# Altium schematic sheet Sheet1.SchDoc(Sheet1)
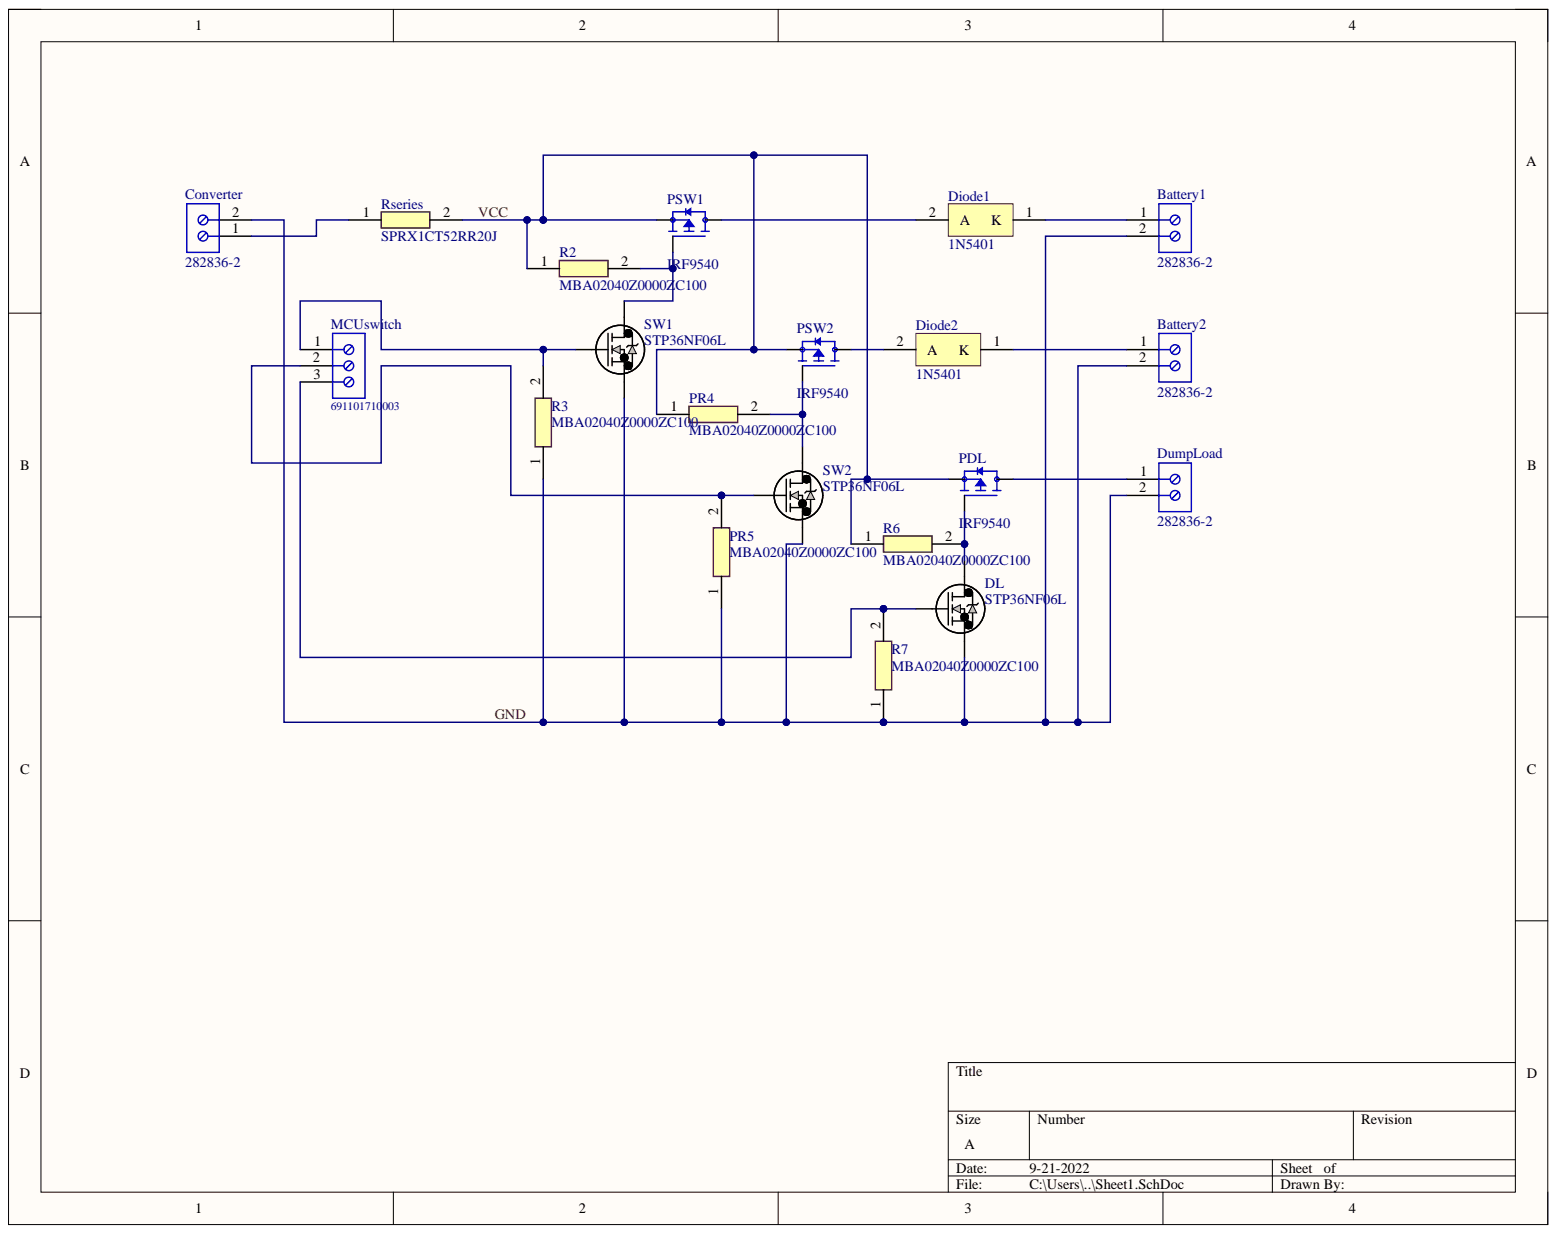
[diagram p0: Sheet1.SchDoc]

## Parts
Battery1  282836-2  [TECO-282836-2_V]
Battery2  282836-2  [TECO-282836-2_V]
Converter  282836-2  [TECO-282836-2_V]
Diode1  1N5401  [ONSC-AXIAL_LEAD-2-267-05_V]
Diode2  1N5401  [ONSC-AXIAL_LEAD-2-267-05_V]
DL  STP36NF06L  [TO-220_STM]
DumpLoad  282836-2  [TECO-282836-2_V]
MCUswitch  691101710003  [691101710003]
PDL  IRF9540  [TO254P1052X465X1989-3]
PR4  MBA02040Z0000ZC100  [RESAD1000W50L360D160]
PR5  MBA02040Z0000ZC100  [RESAD1000W50L360D160]
PSW1  IRF9540  [TO254P1052X465X1989-3]
PSW2  IRF9540  [TO254P1052X465X1989-3]
R2  MBA02040Z0000ZC100  [RESAD1000W50L360D160]
R3  MBA02040Z0000ZC100  [RESAD1000W50L360D160]
R6  MBA02040Z0000ZC100  [RESAD1000W50L360D160]
R7  MBA02040Z0000ZC100  [RESAD1000W50L360D160]
Rseries  SPRX1CT52RR20J  [RESAD2300W80L900D350]
SW1  STP36NF06L  [TO-220_STM]
SW2  STP36NF06L  [TO-220_STM]

## Nets
GND: Battery1.2 Battery2.2 Converter.2 DL.3 DumpLoad.2 PR5.1 R3.1 R7.1 SW1.3 SW2.3
VCC: PDL.3 PR4.1 PSW1.3 PSW2.3 R2.1 R6.1 Rseries.2
NetBattery1_1: Battery1.1 Diode1.1
NetBattery2_1: Battery2.1 Diode2.1
NetConverter_1: Converter.1 Rseries.1
NetDiode1_2: Diode1.2 PSW1.2
NetDiode2_2: Diode2.2 PSW2.2
NetDL_1: DL.1 MCUswitch.3 R7.2
NetDL_2: DL.2 PDL.1 R6.2
NetDumpLoad_1: DumpLoad.1 PDL.2
NetMCUswitch_1: MCUswitch.1 R3.2 SW1.1
NetMCUswitch_2: MCUswitch.2 PR5.2 SW2.1
NetPR4_2: PR4.2 PSW2.1 SW2.2
NetPSW1_1: PSW1.1 R2.2 SW1.2

## Library data of the parts
Battery1 Battery2 Converter DumpLoad = CMP-1684-00017-1: Female Header, Pitch 5 mm, 1 x 2 Position, Height 10 mm, Tail Length 3.5 mm, Rohs, Bulk; Manufacturer: TE Connectivity; 3D Model: 282836-2.pdf; Clamp Material - Plating: Brass-NickelPlated; Current: 13.5A; Dynamic Catalog: BuchananSeries; Featured Product: EurostyleTerminalBlockConnectors; Mating Orientation: HorizontalwithBoard; Number of Levels: 1; Other Names: 5ULV-02B  A98076; Pitch: 0.197"(5.00mm); Positions Per Level: 2; Product Training Modules: Wire-to-BoardEurostyleTerminalBlocks; Screw Thread: M3; Torque - Screw: 0.68Nm(6Lb-In); Voltage: 300V; Wire Gauge: 16-30AWG; Wire Termination: Screw-RisingCageClamp; Category: Connectors,Interconnects; Color: Green; Component Kind: Standard; Contact Material - Plating: Brass-TinPlated; Datasheet URL: http://www.te.com.cn/commerce/DocumentDelivery/DDEController?Action=showdoc&DocId=Catalog+Section%7F1308389_EUROSTYLE_TERMINAL_BLOCKS%7F0607%7Fpdf%7FEnglish%7FENG_CS_1308389_EUROSTYLE_TERMINAL_BLOCKS_0607.pdf%7F282836-2; Family: TerminalBlocks-WiretoBoard; Features: Interlocking(Side); Housing Material: Polyamide(PA66),Nylon6/6; Library Name: Altium Content Vault; Manufacturer URL: http://www.te.com; Mounting Type: ThroughHole; Package Description: Female Header, Pitch 5 mm, 1 x 2 Position, Height 10 mm, Tail Length 3.5 mm; Package Reference: THD; Packaging: Bulk; RoHS Information: 282836-2StatementofCompliance; Screw Material - Plating: Brass-NickelPlated; Series: Buchanan; Standard Package: 300
Diode1 Diode2 = CMP-0902-00078-1: Standard Recovery Rectifier, 2-Pin Axial_Lead, Pb-Free, Bulk Box; Manufacturer: On Semiconductor; IFSM Max (A): 200; IO(rec) Max (A): 3; VRRM Max (V): 100; Component Kind: Standard; ComponentLink1Description: Manufacturer URL; ComponentLink1URL: http://www.onsemi.com/; ComponentLink2Description: Datasheet; ComponentLink2URL: http://www.onsemi.com/pub_link/Collateral/1N5400-D.PDF; ComponentLink3Description: Package Specification; ComponentLink3URL: http://www.onsemi.com/pub_link/Collateral/267-05.PDF; DatasheetVersion: Rev. 10; Library Name: Altium Content Vault; Mounting Technology: Through Hole; PackageDescription: 2-Pin, Axial Lead, Diameter 5.05 mm; PackageReference: AXIAL_LEAD-2-267-05; PackageVersion: Rev. G, 06/2000; Packing: Bulk Box; PartNumber: 1N5401; RoHS: TRUE; Type: Standard Recovery
DL SW1 SW2 = STP36NF06L: No Description Available; Mfr_Name: STMicroelectronics; Component Kind: Standard; Digi-Key_Part_Number_1: 497-7522-5-ND; Library Name: Schlib1.SchLib; Manufacturer_Part_Number: STP36NF06L; RefDes: RefDes; Type: DEV; Copyright: Copyright (C) 2022 Ultra Librarian. All rights reserved.
MCUswitch = CMP-1502-03257-1: Series 101 - 5.00 mm Horizontal Entry Modular with Pressure Clamp WR-TBL, 3 pin; Manufacturer: Wurth Elektronik; Pin Number: 3; Pitch: 5.00mm; Component Kind: Standard; Datasheet URL: http://katalog.we-online.de/em/datasheet/6911017100xx.pdf; Library Name: Altium Content Vault; Manufacturer URL: http://www.we-online.de; Mounting Technology: THT; Operating Temperature: -40to105degC; Package Reference: 691101710003; Packaging: Box; Part Number: 691101710003; Publisher: WurthElektronik
PDL PSW1 PSW2 = IRF9540: P-Channel 100V 19A (Tc) 150W (Tc) Through Hole TO-220AB; MF: Vishay Siliconix; Component Kind: Standard; Digi-Key_Part_Number: IRF9540PBF-ND; Library Name: IRF9540.IntLib; MP: IRF9540; Package: TO-220 International Rectifier; SnapEDA_Link: https://www.snapeda.com/parts/IRF9540/Vishay%20Siliconix/view-part/1087953/?ref=snap
PR4 PR5 R2 R3 R6 R7 = MBA02040Z0000ZC100: Resistor; Manufacturer_Name: Vishay; Component Kind: Standard; Datasheet Link: https://www.vishay.com/docs/28766/mbxsma.pdf; Manufacturer_Part_Number: MBA02040Z0000ZC100
Rseries = SPRX1CT52RR20J: Resistor; Manufacturer_Name: KOA Speer; Component Kind: Standard; Datasheet Link: https://componentsearchengine.com/Datasheets/1/SPRX1CT52RR20J.pdf; Manufacturer_Part_Number: SPRX1CT52RR20J
